# Altium schematic sheet SparkIO Thermal Shield - No Aux ADC.SchDoc
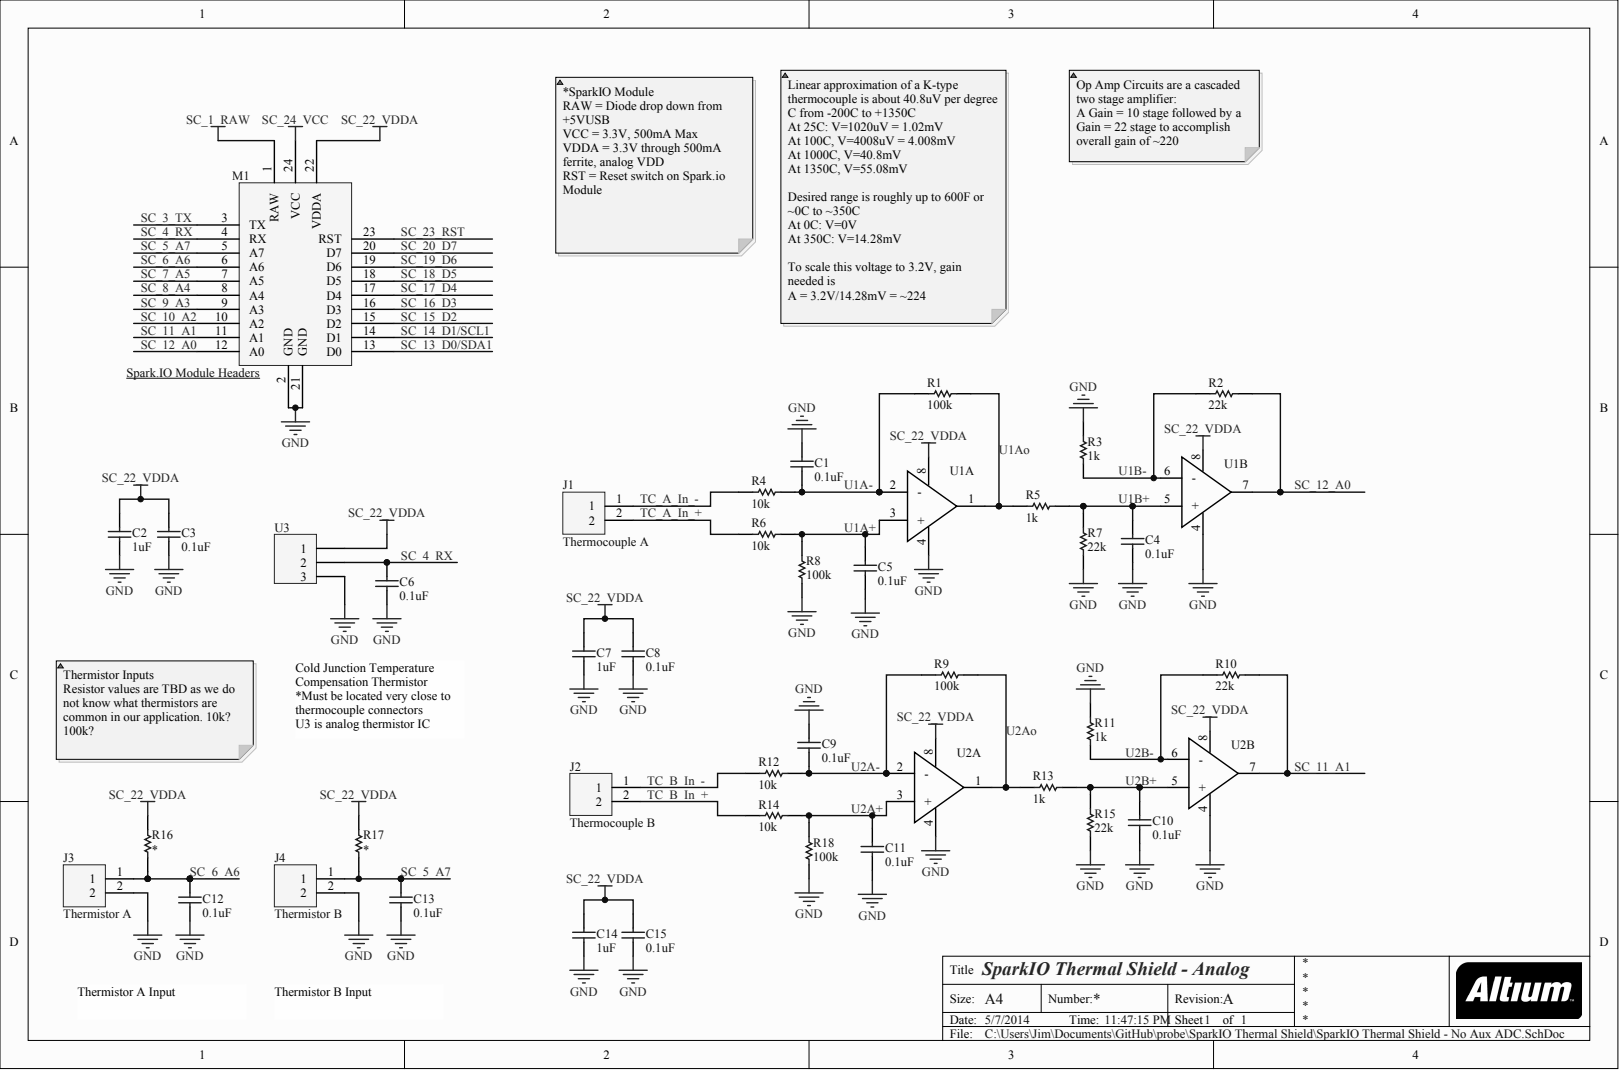
[diagram p0: SparkIO Thermal Shield - No Aux ADC.SchDoc]

## Parts
C1  value 0.1uF  (hidden comment: Capacitor)
C2  value 1uF  (hidden comment: Capacitor)
C3  value 0.1uF  (hidden comment: Capacitor)
C4  value 0.1uF  (hidden comment: Capacitor)
C5  value 0.1uF  (hidden comment: Capacitor)
C6  value 0.1uF  (hidden comment: Capacitor)
C7  value 1uF  (hidden comment: Capacitor)
C8  value 0.1uF  (hidden comment: Capacitor)
C9  value 0.1uF  (hidden comment: Capacitor)
C10  value 0.1uF  (hidden comment: Capacitor)
C11  value 0.1uF  (hidden comment: Capacitor)
C12  value 0.1uF  (hidden comment: Capacitor)
C13  value 0.1uF  (hidden comment: Capacitor)
C14  value 1uF  (hidden comment: Capacitor)
C15  value 0.1uF  (hidden comment: Capacitor)
J1  Thermocouple A
J2  Thermocouple B
J3  Thermistor A
J4  Thermistor B
M1  Spark.IO Module Headers
R1  Resistor  value 100k
R2  Resistor  value 22k
R3  Resistor  value 1k
R4  Resistor  value 10k
R5  Resistor  value 1k
R6  Resistor  value 10k
R7  Resistor  value 22k
R8  Resistor  value 100k
R9  Resistor  value 100k
R10  Resistor  value 22k
R11  Resistor  value 1k
R12  Resistor  value 10k
R13  Resistor  value 1k
R14  Resistor  value 10k
R15  Resistor  value 22k
R16  Resistor
R17  Resistor
R18  Resistor  value 100k
U1  OPAMP dual  (value not drawn)  (parts A,B)
U2  OPAMP dual  (value not drawn)  (parts A,B)
U3  Header 3  (value not drawn)

## Nets
GND: C1.1 C2.2 C3.2 C4.2 C5.2 C6.2 C7.2 C8.2 C9.1 C10.2 C11.2 C12.2 C13.2 C14.2 C15.2 J3.2 J4.2 M1.2(GND) M1.21(GND) R3.1 R7.1 R8.1 R11.1 R15.1 R18.1 U1.4 U2.4 U3.3
SC_1_RAW: M1.1(RAW)
SC_22_VDDA: C2.1 C3.1 C7.1 C8.1 C14.1 C15.1 M1.22(VDDA) R16.1 R17.1 U1.8 U2.8 U3.1
SC_24_VCC: M1.24(VCC)
SC_3_TX: M1.3(TX)
SC_4_RX: C6.1 M1.4(RX) U3.2
SC_5_A7: C13.1 J4.1 M1.5(A7) R17.2
SC_6_A6: C12.1 J3.1 M1.6(A6) R16.2
SC_7_A5: M1.7(A5)
SC_8_A4: M1.8(A4)
SC_9_A3: M1.9(A3)
SC_10_A2: M1.10(A2)
SC_11_A1: M1.11(A1) R10.1 U2.7
SC_12_A0: M1.12(A0) R2.1 U1.7
SC_13_D0/SDA1: M1.13(D0)
SC_14_D1/SCL1: M1.14(D1)
SC_15_D2: M1.15(D2)
SC_16_D3: M1.16(D3)
SC_17_D4: M1.17(D4)
SC_18_D5: M1.18(D5)
SC_19_D6: M1.19(D6)
SC_20_D7: M1.20(D7)
SC_23_RST: M1.23(RST)
TC_A_IN_+: J1.2 R6.2
TC_A_IN_-: J1.1 R4.2
TC_B_IN_+: J2.2 R14.2
TC_B_IN_-: J2.1 R12.2
U1A+: C5.1 R6.1 R8.2 U1.3(+)
U1A-: C1.2 R1.2 R4.1 U1.2(-)
U1AO: R1.1 R5.2 U1.1
U1B+: C4.1 R5.1 R7.2 U1.5
U1B-: R2.2 R3.2 U1.6
U2A+: C11.1 R14.1 R18.2 U2.3(+)
U2A-: C9.2 R9.2 R12.1 U2.2(-)
U2AO: R9.1 R13.2 U2.1
U2B+: C10.1 R13.1 R15.2 U2.5
U2B-: R10.2 R11.2 U2.6

## Library data of the parts
U3 = (no symbol name): Units_per_part: 40; Units_per_component: 3; Name: .100 breakaway header
C1-C15 J1-J4 M1 R1-R18 U1 U2 = (no symbol name)
  R16 R17: Value: *
